# Altium schematic sheet FPGA_JTAG.SchDoc
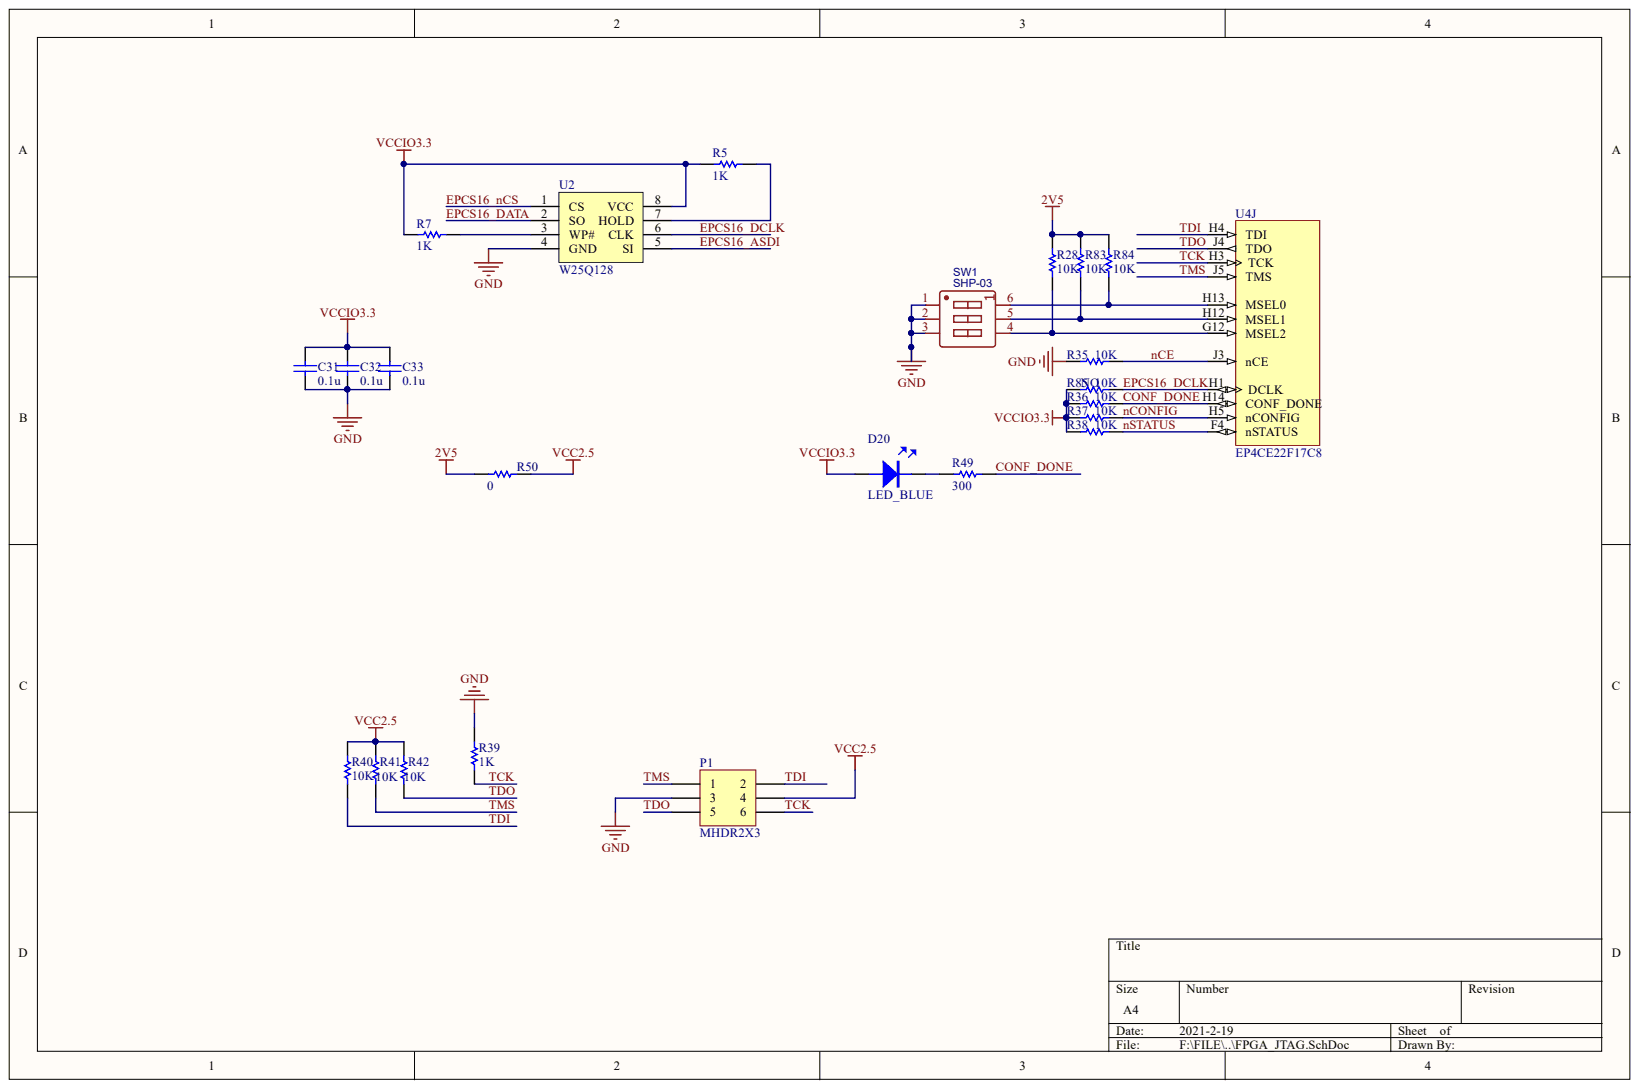
[diagram p0: FPGA_JTAG.SchDoc]

## Parts
C31  0.1u  [C0402]
C32  0.1u  [C0402]
C33  0.1u  [C0402]
D20  LED_BLUE  [LED-0603B]
P1  MHDR2X3  [MHDR2X3]
R5  1K  [R0402]
R7  1K  [R0402]
R28  10K  [R0402]
R35  10K  [R0402]
R36  10K  [R0402]
R37  10K  [R0402]
R38  10K  [R0402]
R39  1K  [R0402]
R40  value 10K  [R0402]  (hidden comment: Res1)
R41  value 10K  [R0402]  (hidden comment: Res1)
R42  value 10K  [R0402]  (hidden comment: Res1)
R49  300  [R0402]
R50  0  [R0402]
R83  10K  [R0402]
R84  10K  [R0402]
R85  10K  STUFF: NO  [R0402]
SW1  SHP-03  [SW-SMD_SHP-03]
U2  W25Q128  [SOIC8]
U4  EP4CE22F17C8  [FBGA256]  (part J)

## Nets
2V5: R28.2 R50.1 R83.2 R84.2
GND: C31.1 C32.1 C33.1 P1.3 R35.2 R39.2 SW1.1 SW1.2 SW1.3 U2.4(GND)
VCC2.5: P1.4 R40.2 R41.2 R42.2 R50.2
VCCIO3.3: C31.2 C32.2 C33.2 D20.A R5.2 R7.2 R36.2 R37.2 R38.2 R85.2 U2.8(VCC)
CONF_DONE: R36.1 R49.2 U4.H14
EPCS16_ASDI: U2.5(SI)
EPCS16_DATA: U2.2(SO)
EPCS16_DCLK: R85.1 U2.6(CLK) U4.H1(DCLK)
EPCS16_NCS: U2.1(CS)
NCE: R35.1 U4.J3(nCE)
NCONFIG: R37.1 U4.H5(nCONFIG)
NSTATUS: R38.1 U4.F4(nSTATUS)
TCK: P1.6 R39.1 U4.H3(TCK)
TDI: P1.2 R40.1 U4.H4(TDI)
TDO: P1.5 R42.1 U4.J4(TDO)
TMS: P1.1 R41.1 U4.J5(TMS)
NetD20_K: D20.K R49.1
NetR5_1: R5.1 U2.7(HOLD)
NetR7_1: R7.1 U2.3(WP#)
NetR28_1: R28.1 SW1.4 U4.G12(MSEL2)
NetR83_1: R83.1 SW1.5 U4.H12(MSEL1)
NetR84_1: R84.1 SW1.6 U4.H13(MSEL0)

## Library data of the parts
C31-C33 = CAP1: Component Kind: Standard; Library Name: the_god_of_death.IntLib
D20 = LED: Component Kind: Standard; Library Name: LED.IntLib
P1 = MHDR2X3: Header, 3-Pin, Dual row; Component Kind: Standard; LatestRevisionDate: 17-Jul-2002; LatestRevisionNote: Re-released for DXP Platform.; Library Name: Miscellaneous Connectors.IntLib; Signal Integrity: Connector; Publisher: Altium Limited
R5 R7 R28 R35-R38 R49 R50 R83-R85 = RES: Component Kind: Standard
  R5 R7: Library Name: 电阻.IntLib
  R28 R35-R38 R49 R50 R83-R85: Library Name: the_god_of_death.IntLib
R39-R42 = Res1: Resistor; Component Kind: Standard; LatestRevisionDate: 17-Jul-2002; LatestRevisionNote: Re-released for DXP Platform.; Library Name: Miscellaneous Devices.IntLib; PackageDescription: Axial Device, Thru-Hole; 2 Leads; 0.3 in Pin Spacing; PackageReference: AXIAL-0.3; Published: 8-Jun-2000; Signal Integrity: Res; Simulation: RESISTOR; Publisher: Altium Limited
  R39: Value: 1K
SW1 = SHP-03: BOM_Manufacturer: Cha; Contributor: LCSC; BOM_Manufacturer Part: SHP-03; BOM_Supplier: LCSC; BOM_Supplier Part: C360672; Component Kind: Standard; spicePre: S; spiceSymbolName: SHP-03
U4 = EP4CE22F17C8: Cyclone IV Family FPGA, 2V Core, 153 I/O Pins, 4 PLLs, 256-Pin FBGA, Speed Grade 8, Commercial Grade; Code_IPC: BGA100P16X16-256; Code_JEDEC: MS-034-AAF-1; Component Kind: Standard; ComponentLink1Description: Manufacturer Link; ComponentLink1URL: http://www.altera.com/; ComponentLink2Description: Handbook; ComponentLink2URL: http://www.altera.com/literature/hb/cyclone-iv/cyclone4-handbook.pdf; ComponentLink3Description: Pinout Specification; ComponentLink3URL: http://www.altera.com/literature/lit-dp.jsp?category=cyc%204&showspreadsheet=y; ComponentLink4Description: Packaging Specification; ComponentLink4URL: http://www.altera.com/literature/ds/dspkg.pdf; DatasheetVersion: ver1.2, Mar-2010; Library Name: Altera Cyclone IV E.SchLib; PackageDescription: 256-Ball FineLine Ball Grid Array (FBGA256) - Option 1 - Wire Bond; PackageReference: FBGA256; PackageVersion: ver16.0, Dec-2009; Published: 3-May-2010; Signal Integrity: EP4CE_F256; Publisher: Altium Limited
U2 = W25Q128: Component Kind: Standard; Library Name: 未分类IC.IntLib
